# Altium schematic sheet 02COM.SchDoc(02COM)
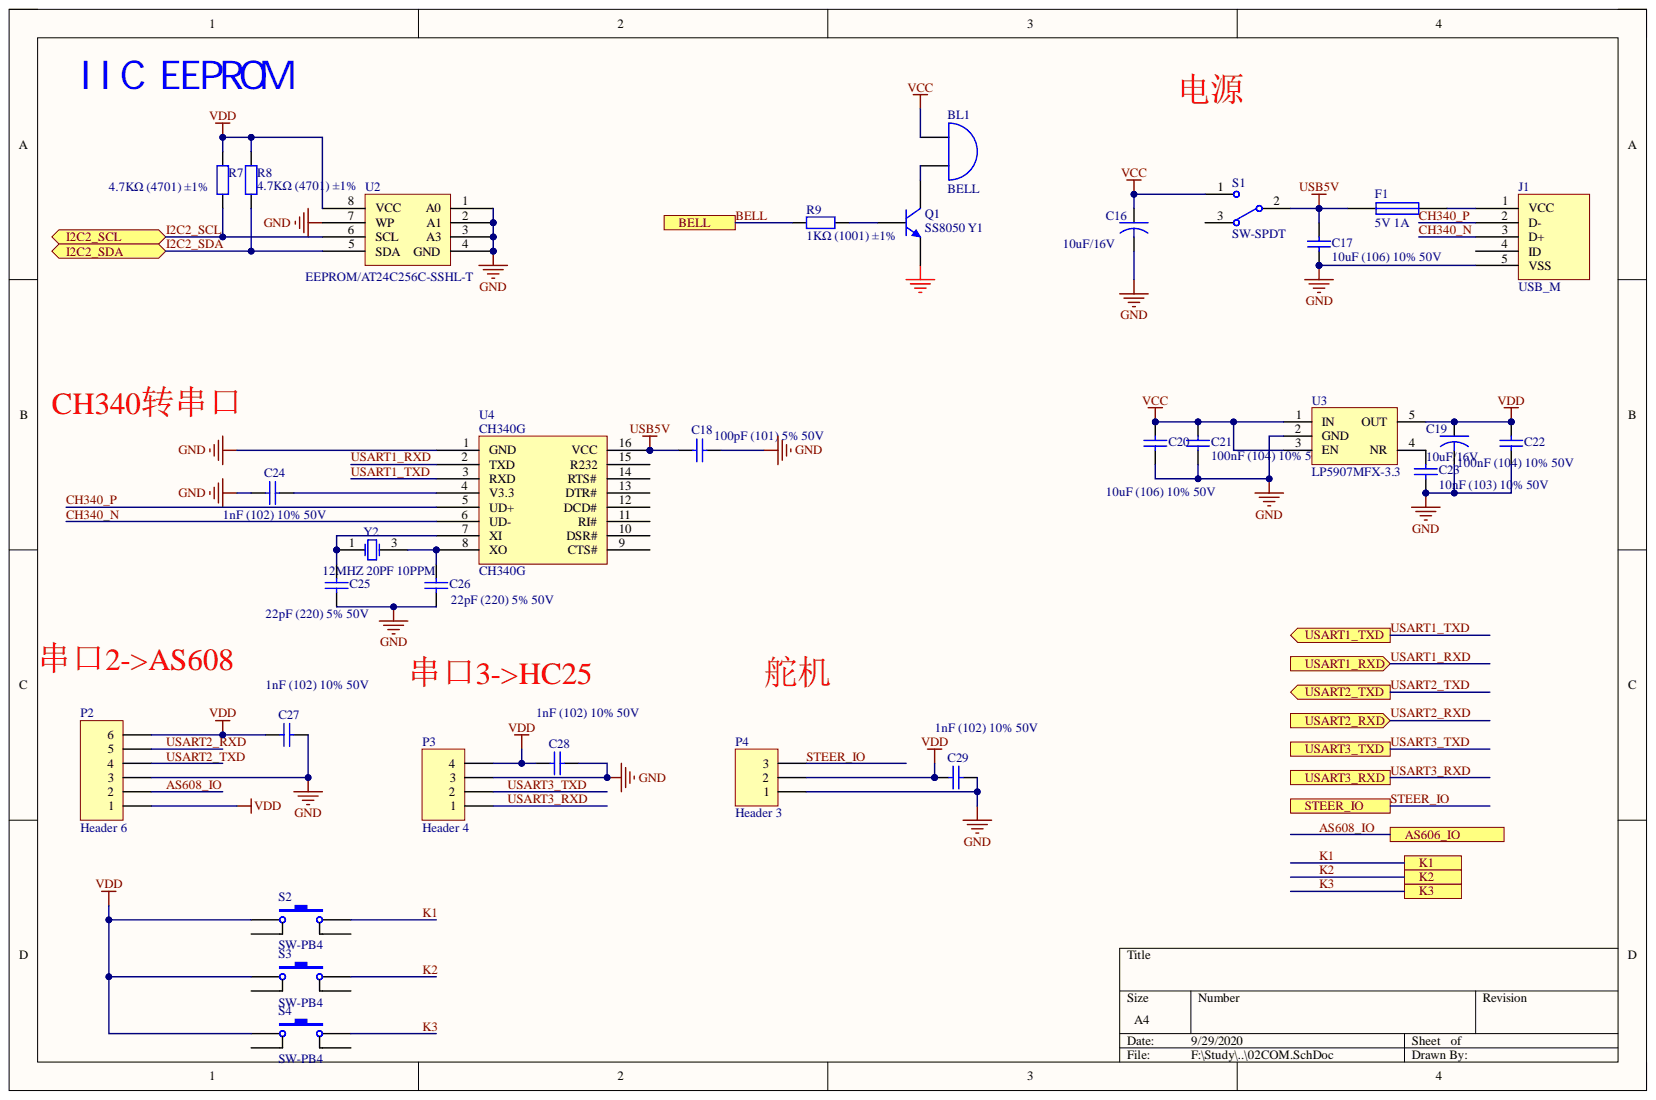
[diagram p0: 02COM.SchDoc]

## Parts
BL1  BELL  [BUZZER]
C16  10uF/16V  [0805_C]
C17  10uF (106) 10% 50V  [Cap]
C18  100pF (101) 5% 50V  [0603_C]
C19  10uF/16V  [0805_C]
C20  10uF (106) 10% 50V  [Cap]
C21  100nF (104) 10% 50V  [0603_C]
C22  100nF (104) 10% 50V  [0603_C]
C23  10nF (103) 10% 50V  [0603_C]
C24  1nF (102) 10% 50V  [0603_C]
C25  22pF (220) 5% 50V  [0603_C]
C26  22pF (220) 5% 50V  [0603_C]
C27  1nF (102) 10% 50V  [0603_C]
C28  1nF (102) 10% 50V  [0603_C]
C29  1nF (102) 10% 50V  [0603_C]
F1  5V 1A  [FUSE3528]
J1  USB_M  [USB_MICRO]
P2  Header 6  [HDR1X6]
P3  Header 4  [HDR1X4]
P4  Header 3  [HDR1X3]
Q1  SS8050 Y1  [SOT-23(SOT-23-3)]
R7  4.7KΩ (4701) ±1%  [0603_R]
R8  4.7KΩ (4701) ±1%  [0603_R]
R9  1KΩ (1001) ±1%  [0603_R]
S1  SW-SPDT  [SWITCH_A1]
S2  SW-PB4  [KEY_6*6]
S3  SW-PB4  [KEY_6*6]
S4  SW-PB4  [KEY_6*6]
U2  EEPROM/AT24C256C-SSHL-T  [SOIC-8_150mil]
U3  LP5907MFX-3.3  [SOT-23-5]
U4  CH340G  [SOIC-16_150mil]
Y2  12MHZ 20PF 10PPM  [SMD-3225_4P]

## Ports
AS606_IO, BELL, I2C2_SCL, I2C2_SDA, K1, K2, K3, STEER_IO, USART1_RXD, USART1_TXD, USART2_RXD, USART2_TXD, USART3_RXD, USART3_TXD

## Nets
GND: C16.2 C17.2 C18.2 C19.2 C20.2 C21.1 C22.1 C23.2 C24.1 C25.1 C26.1 C27.2 C28.2 C29.2 J1.5(VSS) P2.3 P3.3 P4.1 Q1.2 U2.1(A0) U2.2(A1) U2.3(A3) U2.4(GND) U2.7(WP) U3.2(GND) U4.1(GND)
USB5V: C17.1 C18.1 F1.1 S1.2 U4.16(VCC)
VCC: BL1.1 C16.1 C20.1 C21.2 S1.1 U3.1(IN) U3.3(EN)
VDD: C19.1 C22.2 C27.1 C28.1 C29.1 P2.1 P2.6 P3.4 P4.2 R7.1 R8.1 S2.1 S3.1 S4.1 U2.8(VCC) U3.5(OUT)
AS608_IO: P2.2 ; port AS606_IO
BELL: R9.2 ; port BELL
CH340_N: J1.3(D+) U4.6(UD-)
CH340_P: J1.2(D-) U4.5(UD+)
I2C2_SCL: R7.2 U2.6(SCL) ; port I2C2_SCL
I2C2_SDA: R8.2 U2.5(SDA) ; port I2C2_SDA
K1: S2.2 ; port K1
K2: S3.2 ; port K2
K3: S4.2 ; port K3
STEER_IO: P4.3 ; port STEER_IO
USART1_RXD: U4.2(TXD) ; port USART1_RXD
USART1_TXD: U4.3(RXD) ; port USART1_TXD
USART2_RXD: P2.5 ; port USART2_RXD
USART2_TXD: P2.4 ; port USART2_TXD
USART3_RXD: P3.1 ; port USART3_RXD
USART3_TXD: P3.2 ; port USART3_TXD
NetBL1_2: BL1.2 Q1.3
NetC23_1: C23.1 U3.4(NR)
NetC24_2: C24.2 U4.4(V3.3)
NetC25_2: C25.2 U4.7(XI) Y2.1
NetC26_2: C26.2 U4.8(XO) Y2.3
NetF1_2: F1.2 J1.1(VCC)
NetQ1_1: Q1.1 R9.1

Not connected: J1 4(ID); S1 3; S2 3 4; S3 3 4; S4 3 4; U4 9(CTS#) 10(DSR#) 11(RI#) 12(DCD#) 13(DTR#) 14(RTS#) 15(R232)

## Library data of the parts
C16 C19 = CAPACITOR: AVX   TAN; Component Kind: Standard
C17 C20 = 1206 10uF (106) 10% 50V: 贴片电容; Component Kind: Standard; ComponentLink1Description: 供应商链接; ComponentLink1URL: http://www.szlcsc.com/product/details_14236.html; Library Name: Miscellaneous Devices LC.IntLib; Package: 1206; Supplier: LC; SuppliersPartNumber: C13585
C18 = 0402 100pF (101) 5% 50V: 贴片电容; Component Kind: Standard; ComponentLink1Description: 供应商链接; ComponentLink1URL: http://www.szlcsc.com/product/details_1898.html; Library Name: Miscellaneous Devices LC.IntLib; Package: 0402; Supplier: LC; SuppliersPartNumber: C1546
C21 C22 = 0603 100nF (104) 10% 50V: 贴片电容; Component Kind: Standard; ComponentLink1Description: 供应商链接; ComponentLink1URL: http://www.szlcsc.com/product/details_15331.html; Library Name: Cap.SchLib; Package: 0603; Supplier: LC; SuppliersPartNumber: C14663
C23 = 0603 10nF (103) 10% 50V: 贴片电容; Component Kind: Standard; ComponentLink1Description: 供应商链接; ComponentLink1URL: http://www.szlcsc.com/product/details_58143.html; Library Name: Cap.SchLib; Package: 0603; Supplier: LC; SuppliersPartNumber: C57112
C24 C27-C29 = 0402 1nF (102) 10% 50V: 贴片电容; Component Kind: Standard; ComponentLink1Description: 供应商链接; ComponentLink1URL: http://www.szlcsc.com/product/details_1875.html; Library Name: Miscellaneous Devices LC.IntLib; Package: 0402; Supplier: LC; SuppliersPartNumber: C1523
C25 C26 = 0402 22pF (220) 5% 50V: 贴片电容; Component Kind: Standard; ComponentLink1Description: 供应商链接; ComponentLink1URL: http://www.szlcsc.com/product/details_1907.html; Library Name: Miscellaneous Devices LC.IntLib; Package: 0402; Supplier: LC; SuppliersPartNumber: C1555
F1 = FUSE1: LITTELFUSE; Component Kind: Standard
J1 = USB_M: MicroUSB接口; Component Kind: Standard; Library Name: NorguanSCH.lib
P2 = Header 6: Header, 6-Pin; Component Kind: Standard; LatestRevisionDate: 17-Jul-2002; LatestRevisionNote: Re-released for DXP Platform.; Library Name: Miscellaneous Connectors.IntLib; Signal Integrity: Connector; Publisher: Altium Limited
P3 = Header 4: Header, 4-Pin; Component Kind: Standard; LatestRevisionDate: 17-Jul-2002; LatestRevisionNote: Re-released for DXP Platform.; Library Name: Miscellaneous Connectors.IntLib; Signal Integrity: Connector; Publisher: Altium Limited
P4 = Header 3: Header, 3-Pin; Component Kind: Standard; LatestRevisionDate: 17-Jul-2002; LatestRevisionNote: Re-released for DXP Platform.; Library Name: Miscellaneous Connectors.IntLib; Signal Integrity: Connector; Publisher: Altium Limited
Q1 = SOT-23 SS8050 Y1: 三极管; Component Kind: Standard; ComponentLink1Description: 供应商链接; ComponentLink1URL: http://www.szlcsc.com/product/details_2507.html; Library Name: Transistor_NPN.SchLib; Package: SOT-23; Supplier: LC; SuppliersPartNumber: C2150
R7 R8 = 0603 4.7KΩ (4701) 1%: 贴片电阻; Component Kind: Standard; ComponentLink1Description: 供应商链接; ComponentLink1URL: http://www.szlcsc.com/product/details_23889.html; Library Name: RES.SchLib; Package: 0603; Supplier: LC; SuppliersPartNumber: C23162
R9 = 0603 1KΩ (1001) 1%: 贴片电阻; Component Kind: Standard; ComponentLink1Description: 供应商链接; ComponentLink1URL: http://www.szlcsc.com/product/details_21904.html; Library Name: RES.SchLib; Package: 0603; Supplier: LC; SuppliersPartNumber: C21190
S1 = SW-SPDT: 开关; Component Kind: Standard; ComponentLink1Description: Manufacturer Link; ComponentLink1URL: http://www.apem.com; DatasheetDocument: 05-Dec-2000; LatestRevisionDate: 17-Dec-2003; LatestRevisionNote: Schematic revised, PCB Footprint replaced.; PackageReference: TL36WW15050; Published: 24-Mar-1999; Library Name: NorguanSCH.lib; Publisher: Altium Limited
S2-S4 = SW-PB4: 微动开关; Component Kind: Standard; LatestRevisionDate: 17-Jul-2002; LatestRevisionNote: Re-released for DXP Platform.; PackageReference: SPST-2; Published: 24-Mar-1999; Library Name: NorguanSCH.lib; Publisher: Altium Limited
U2 = EEPROM/AT24C256C-SSHL-T_[C6482]: EEPROM; Component Kind: Standard; ComponentLink1Description: 供应商链接; ComponentLink1URL: http://www.szlcsc.com/product/details_6947.html; Library Name: IC.SchLib; Package: SOIC-8_150mil; Supplier: LC; SuppliersPartNumber: C6482
U3 = TPS73250-EP: Component Kind: Standard; Library Name: NorguanSCH.lib
U4 = CH340G_[C14267]: USB; Component Kind: Standard; ComponentLink1Description: 供应商链接; ComponentLink1URL: http://www.szlcsc.com/product/details_14927.html; Library Name: Miscellaneous Devices LC.IntLib; Package: SOIC-16_150mil; Supplier: LC; SuppliersPartNumber: C14267
Y2 = SMD-3225_4P  12MHZ 20PF 10PPM: 贴片晶振; Component Kind: Standard; ComponentLink1Description: 供应商链接; ComponentLink1URL: http://www.szlcsc.com/product/details_9522.html; Library Name: Miscellaneous Devices LC.IntLib; Package: SMD-3225_4P; Supplier: LC; SuppliersPartNumber: C9002
BL1 = BELL: Component Kind: Standard; Description: Bell
